# Altium schematic sheet SENSORS_SMALL_SCH.SchDoc
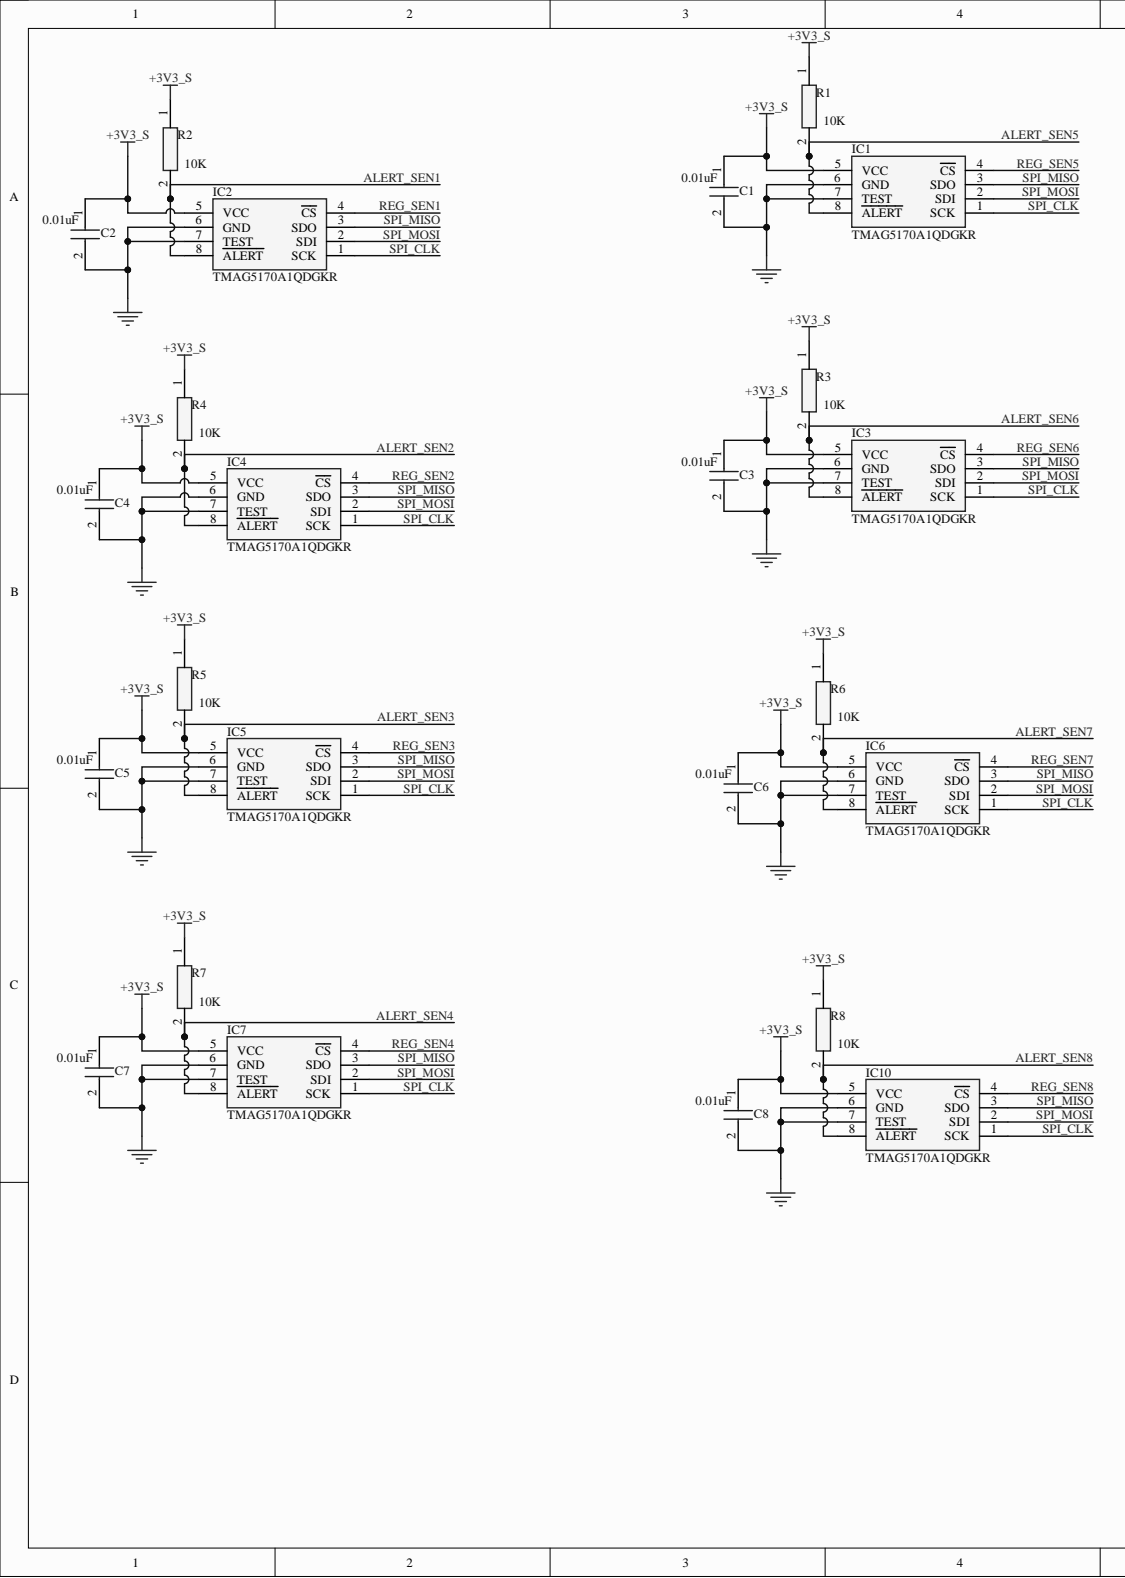
[diagram p0: SENSORS_SMALL_SCH.SchDoc, left]
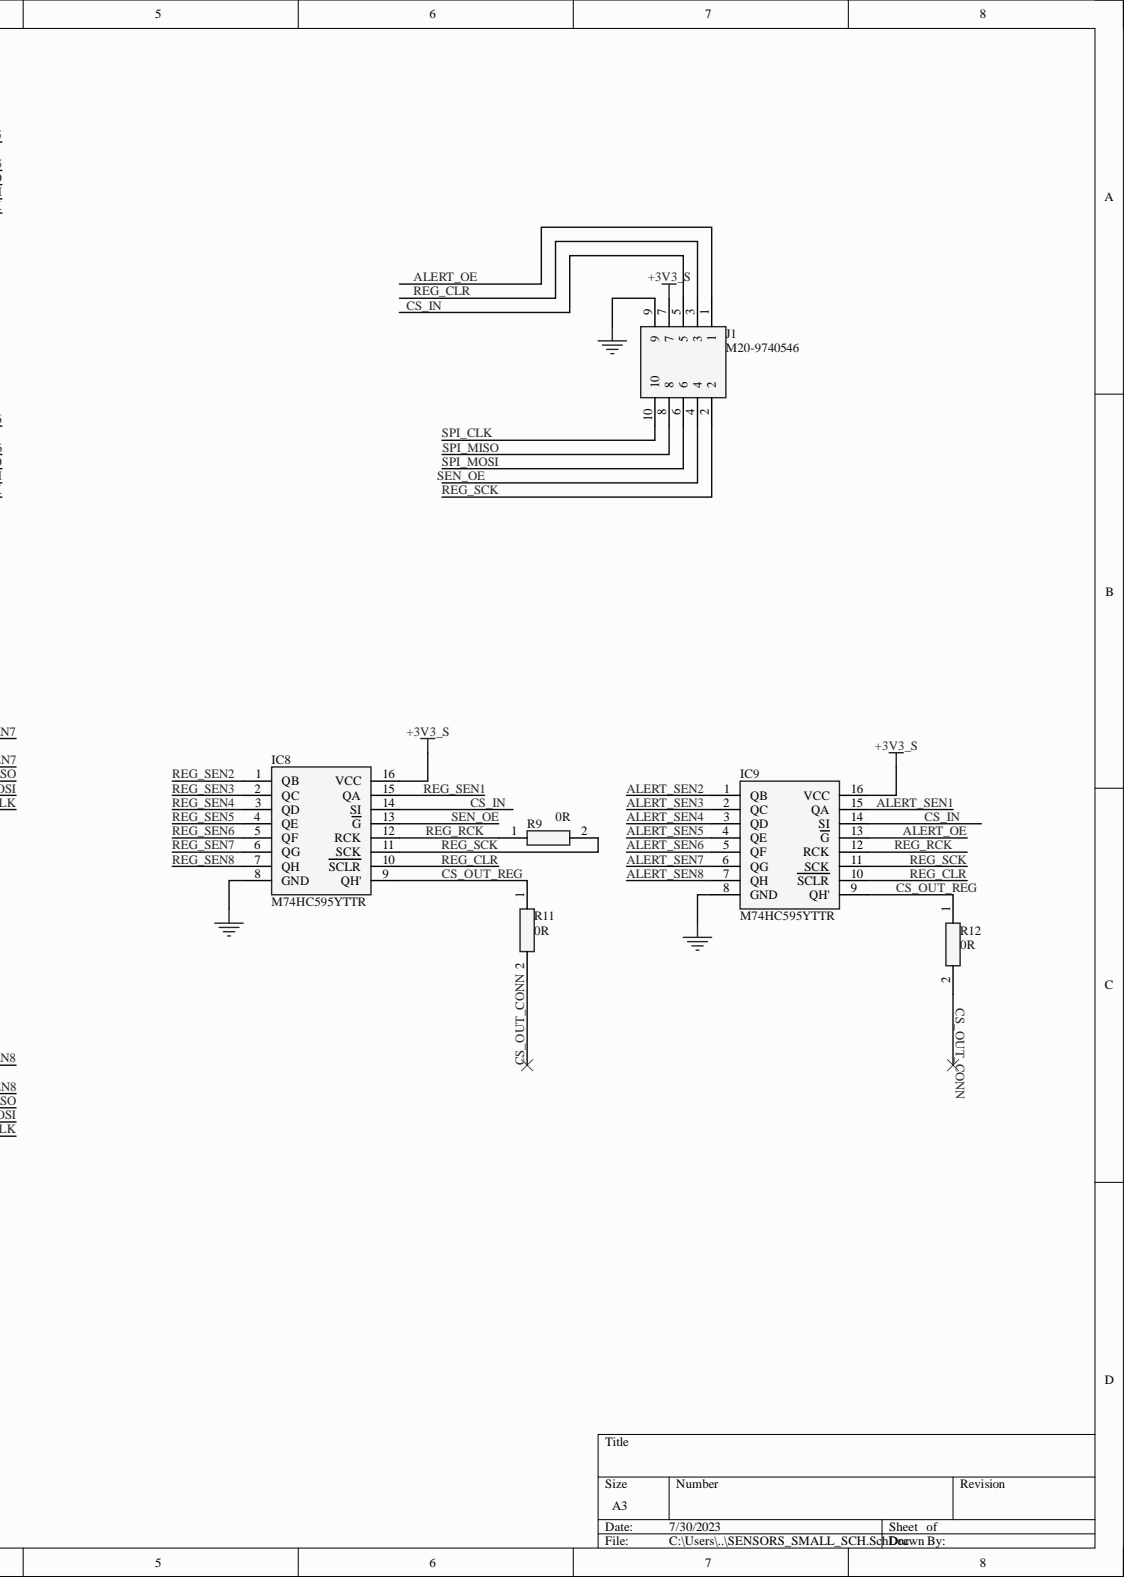
[diagram p1: SENSORS_SMALL_SCH.SchDoc, right]

## Parts
C1  0.01uF  [CAPC2012X88N]
C2  0.01uF  [CAPC2012X88N]
C3  0.01uF  [CAPC2012X88N]
C4  0.01uF  [CAPC2012X88N]
C5  0.01uF  [CAPC2012X88N]
C6  0.01uF  [CAPC2012X88N]
C7  0.01uF  [CAPC2012X88N]
C8  0.01uF  [CAPC2012X88N]
IC1  TMAG5170A1QDGKR  [SOP65P490X110-8N]
IC2  TMAG5170A1QDGKR  [SOP65P490X110-8N]
IC3  TMAG5170A1QDGKR  [SOP65P490X110-8N]
IC4  TMAG5170A1QDGKR  [SOP65P490X110-8N]
IC5  TMAG5170A1QDGKR  [SOP65P490X110-8N]
IC6  TMAG5170A1QDGKR  [SOP65P490X110-8N]
IC7  TMAG5170A1QDGKR  [SOP65P490X110-8N]
IC8  M74HC595YTTR  [SOP65P640X120-16N]
IC9  M74HC595YTTR  [SOP65P640X120-16N]
IC10  TMAG5170A1QDGKR  [SOP65P490X110-8N]
J1  M20-9740546  [HDRRA10W66P254X254_2X5_1270X254X528P]
R1  10K  [RESC1608X55N]
R2  10K  [RESC1608X55N]
R3  10K  [RESC1608X55N]
R4  10K  [RESC1608X55N]
R5  10K  [RESC1608X55N]
R6  10K  [RESC1608X55N]
R7  10K  [RESC1608X55N]
R8  10K  [RESC1608X55N]
R9  0R  [RESC1608X55N]
R11  0R  [RESC1608X55N]
R12  0R  [RESC1608X55N]

## Nets
+3V3_S: C1.1 C2.1 C3.1 C4.1 C5.1 C6.1 C7.1 C8.1 IC1.5(VCC) IC2.5(VCC) IC3.5(VCC) IC4.5(VCC) IC5.5(VCC) IC6.5(VCC) IC7.5(VCC) IC8.16(VCC) IC9.16(VCC) IC10.5(VCC) J1.7 R1.1 R2.1 R3.1 R4.1 R5.1 R6.1 R7.1 R8.1
GND: C1.2 C2.2 C3.2 C4.2 C5.2 C6.2 C7.2 C8.2 IC1.6(GND) IC1.7(TEST) IC2.6(GND) IC2.7(TEST) IC3.6(GND) IC3.7(TEST) IC4.6(GND) IC4.7(TEST) IC5.6(GND) IC5.7(TEST) IC6.6(GND) IC6.7(TEST) IC7.6(GND) IC7.7(TEST) IC8.8(GND) IC9.8(GND) IC10.6(GND) IC10.7(TEST) J1.9
ALERT_OE: IC9.13(G) J1.1
ALERT_SEN1: IC2.8(ALERT) IC9.15(QA) R2.2
ALERT_SEN2: IC4.8(ALERT) IC9.1(QB) R4.2
ALERT_SEN3: IC5.8(ALERT) IC9.2(QC) R5.2
ALERT_SEN4: IC7.8(ALERT) IC9.3(QD) R7.2
ALERT_SEN5: IC1.8(ALERT) IC9.4(QE) R1.2
ALERT_SEN6: IC3.8(ALERT) IC9.5(QF) R3.2
ALERT_SEN7: IC6.8(ALERT) IC9.6(QG) R6.2
ALERT_SEN8: IC9.7(QH) IC10.8(ALERT) R8.2
CS_IN: IC8.14(SI) IC9.14(SI) J1.5
CS_OUT_CONN: R11.2 R12.2
CS_OUT_REG: IC8.9(QH') IC9.9(QH') R11.1 R12.1
REG_CLR: IC8.10(SCLR) IC9.10(SCLR) J1.3
REG_RCK: IC8.12(RCK) IC9.12(RCK) R9.1
REG_SCK: IC8.11(SCK) IC9.11(SCK) J1.2 R9.2
REG_SEN1: IC2.4(CS) IC8.15(QA)
REG_SEN2: IC4.4(CS) IC8.1(QB)
REG_SEN3: IC5.4(CS) IC8.2(QC)
REG_SEN4: IC7.4(CS) IC8.3(QD)
REG_SEN5: IC1.4(CS) IC8.4(QE)
REG_SEN6: IC3.4(CS) IC8.5(QF)
REG_SEN7: IC6.4(CS) IC8.6(QG)
REG_SEN8: IC8.7(QH) IC10.4(CS)
SEN_OE: IC8.13(G) J1.4
SPI_CLK: IC1.1(SCK) IC2.1(SCK) IC3.1(SCK) IC4.1(SCK) IC5.1(SCK) IC6.1(SCK) IC7.1(SCK) IC10.1(SCK) J1.10
SPI_MISO: IC1.3(SDO) IC2.3(SDO) IC3.3(SDO) IC4.3(SDO) IC5.3(SDO) IC6.3(SDO) IC7.3(SDO) IC10.3(SDO) J1.8
SPI_MOSI: IC1.2(SDI) IC2.2(SDI) IC3.2(SDI) IC4.2(SDI) IC5.2(SDI) IC6.2(SDI) IC7.2(SDI) IC10.2(SDI) J1.6

## Library data of the parts
C1-C8 = C0805C103J5RAC7025: Capacitor; Manufacturer_Name: KEMET; Component Kind: Standard; Datasheet Link: https://componentsearchengine.com/Datasheets/1/C0805C103J5RAC7025.pdf; Height: 0.88mm; Library Name: SamacSys.SchLib; Manufacturer_Part_Number: C0805C103J5RAC7025; Mouser Part Number: 80-C0805C103J5RACTM; Mouser Price/Stock: https://www.mouser.co.uk/ProductDetail/KEMET/C0805C103J5RAC7025?qs=OST0ycsSJhUuY%252B8klXMlUw%3D%3D
IC1-IC7 IC10 = TMAG5170A1QDGKR: Integrated Circuit; Manufacturer_Name: Texas Instruments; Arrow Part Number: TMAG5170A1QDGKR; Arrow Price/Stock: https://www.arrow.com/en/products/tmag5170a1qdgkr/texas-instruments?region=nac; Component Kind: Standard; Datasheet Link: https://www.ti.com/lit/ds/symlink/tmag5170.pdf?ts=1631468164599&ref_url=https%253A%252F%252Fwww.ti.com%252Fsitesearch%252Fdocs%252Funiversalsearch.tsp%253FlangPref%253Den-US%2526searchTerm%253DTMAG5170A1QDGKR%2526nr%253D4; Height: 1.1mm; Manufacturer_Part_Number: TMAG5170A1QDGKR; Mouser Part Number: 595-TMAG5170A1QDGKR; Mouser Price/Stock: https://www.mouser.co.uk/ProductDetail/Texas-Instruments/TMAG5170A1QDGKR?qs=7D1LtPJG0i2KumggWL5Glw%3D%3D
IC8 IC9 = M74HC595YTTR: Integrated Circuit; Manufacturer_Name: STMicroelectronics; Arrow Part Number: M74HC595YTTR; Arrow Price/Stock: https://www.arrow.com/en/products/m74hc595yttr/stmicroelectronics; Component Kind: Standard; Datasheet Link: https://componentsearchengine.com/Datasheets/1/M74HC595YTTR.pdf; Height: 1.2mm; Manufacturer_Part_Number: M74HC595YTTR; Mouser Part Number: 511-M74HC595YTTR; Mouser Price/Stock: https://www.mouser.co.uk/ProductDetail/STMicroelectronics/M74HC595YTTR?qs=WHlX%252B%252B9%2FRwCpo6YE5YKRQQ%3D%3D
J1 = M20-9740546: Connector; Manufacturer_Name: Harwin; Component Kind: Standard; Datasheet Link: https://cdn.harwin.com/pdfs/M20-974.pdf; Height: 5.28mm; Manufacturer_Part_Number: M20-9740546; Mouser Part Number: 855-M20-9740546; Mouser Price/Stock: https://www.mouser.co.uk/ProductDetail/Harwin/M20-9740546?qs=Jph8NoUxIfWt9LqcR4oT%2Fg%3D%3D
R1-R9 R11 R12 = RK73B1JTTD103J: Resistor; Manufacturer_Name: KOA Speer; Arrow Part Number: RK73B1JTTD103J; Arrow Price/Stock: https://www.arrow.com/en/products/rk73b1jttd103j/koa-speer-electronics?region=asia; Component Kind: Standard; Datasheet Link: http://www.koaspeer.com/catimages/Products/RK73-RT/RK73-RT.pdf; Height: 0.55mm; Manufacturer_Part_Number: RK73B1JTTD103J; Mouser Part Number: 660-RK73B1JTTD103J; Mouser Price/Stock: https://www.mouser.co.uk/ProductDetail/KOA-Speer/RK73B1JTTD103J?qs=hmtrJ2UjXcBKRTcwO9LloQ%3D%3D
